# Altium schematic sheet IMU.SchDoc
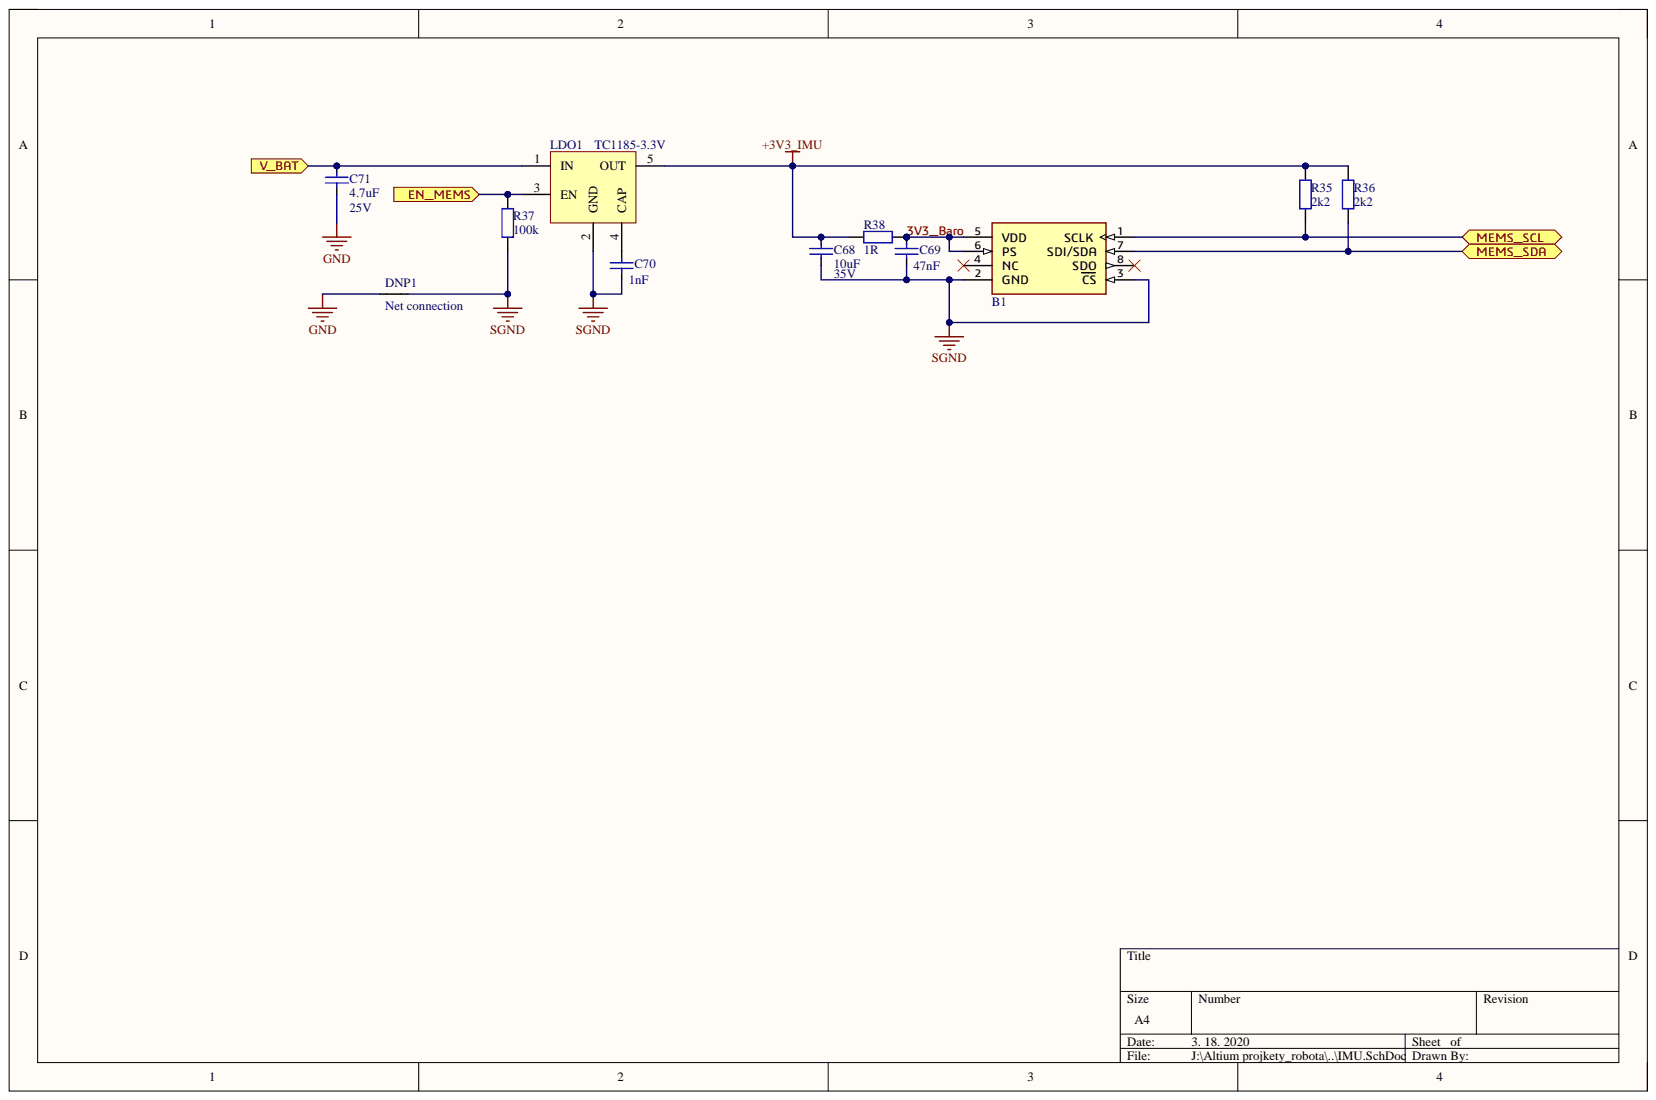
[diagram p0: IMU.SchDoc]

## Parts
B1  Pressure sensor  [MS580314]  (value not drawn)
C68  10uF  Voltage Rating: 35V  [CAPACITOR_0805_(2012)]
C69  47nF  [CAPACITOR_0603_(1608)]
C70  1nF  [CAPACITOR_0603_(1608)]
C71  4.7uF  Voltage: 25V  [CAPACITOR_0603_(1608)]
DNP1  Net connection  [Net connection 1mm]
LDO1  TC1185-3.3V  [SOT23-5]
R35  2k2  [RESISTOR_0603_(1608)]
R36  2k2  [RESISTOR_0603_(1608)]
R37  100k  [RESISTOR_0603_(1608)]
R38  1R  [RESISTOR_0603_(1608)]

## Ports
EN_MEMS, MEMS_SCL, MEMS_SDA, V_BAT

## Nets
GND: C71.2 DNP1.1
SGND: B1.2(GND) B1.3(CS) C68.2 C69.2 C70.2 DNP1.2 LDO1.2(GND) R37.1
3V3_Baro: B1.5(VDD) B1.6(PS) C68.1 C69.1 LDO1.5(OUT) R35.1 R36.1 R38.1 R38.2
EN_MEMS: LDO1.3(EN) R37.2 ; port EN_MEMS
MEMS_SCL: B1.1(SCLK) R35.2 ; port MEMS_SCL
MEMS_SDA: B1.7(SDI/SDA) R36.2 ; port MEMS_SDA
V_BAT: C71.1 LDO1.1(IN) ; port V_BAT
NetC70_1: C70.1 LDO1.4(CAP)

Not connected: B1 4(NC) 8(SDO)

## Library data of the parts
B1 = MS5803: Miniature 14 bar Module; Component Kind: Standard; Library Name: BB1_SCH_Library.SchLib
C68 = CAPACITOR: Manufacturer 1: Murata; Manufacturer Part Number 1: GRM21BR6YA106KE43L; Dielectric: X5R; Tolerance: 10%; Capacitance: 10uF; Case Code (Imperial): 0805; Case Code (Metric): 2012; Case/Package: 0805; Component Kind: Standard; ComponentLink1Description: http://www.farnell.com/datasheets/2079187.pdf?src-supplier=Farnell; ComponentLink1URL: http://www.farnell.com/datasheets/2079187.pdf?src-supplier=Farnell; ComponentLink2Description: http://www.mouser.com/ds/2/281/c02e-2905.pdf?src-supplier=Mouser; ComponentLink2URL: http://www.mouser.com/ds/2/281/c02e-2905.pdf?src-supplier=Mouser; ComponentLink3Description: http://search.murata.co.jp/Ceramy/image/img/A01X/G101/ENG/GRM21BR6YA106KE43-01.pdf?src-supplier=Digi-Key; ComponentLink3URL: http://search.murata.co.jp/Ceramy/image/img/A01X/G101/ENG/GRM21BR6YA106KE43-01.pdf?src-supplier=Digi-Key; ComponentLink4Description: http://search.murata.co.jp/Ceramy/image/img/A01X/partnumbering_e_01.pdf?src-supplier=Digi-Key; ComponentLink4URL: http://search.murata.co.jp/Ceramy/image/img/A01X/partnumbering_e_01.pdf?src-supplier=Digi-Key; ComponentLink5Description: http://www.farnell.com/datasheets/2079187.pdf?src-supplier=Newark; ComponentLink5URL: http://www.farnell.com/datasheets/2079187.pdf?src-supplier=Newark; ComponentLink6Description: http://www.farnell.com/datasheets/2079187.pdf?src-supplier=Element14; ComponentLink6URL: http://www.farnell.com/datasheets/2079187.pdf?src-supplier=Element14; Description: CAPACITOR; Height: 1.25mm; Lead Free: Lead Free; Length: 0.079inch; Max Operating Temperature: 85°C; Min Operating Temperature: -55°C; Mount: Surface Mount; Package Quantity: 3000; Packaging: Tape and Reel; Pricing 1: 5=0,38700, 50=0,21000, 250=0,18000, 500=0,11500, 1500=0,10500, 3000=0,09410, 15000=0,09070 (EUR); REACH SVHC: No SVHC; RoHS: Yes; Stock 1: 109244; Supplier 1: Farnell; Supplier Part Number 1: 2611943; Termination: SMD/SMT; Thickness: 0.057inch; Voltage Rating (DC): 35V; Weight: 0.000335oz; Width: 0.049inch; Library Name: RLCFB.SchLib
C69 C70 = CAPACITOR: ESR: 0.28R; Voltage Rating: 10V; Component Kind: Standard; Description: CAPACITOR; Library Name: RLCFB.SchLib
  C69: Capacitance: 47nF
  C70: Capacitance: 1nF
C71 = CAPACITOR: Manufacturer 1: Murata; Manufacturer Part Number 1: GRM188R61E475KE11D; Dielectric: X5R; ESR: 0.28R; Material: Ceramic; Tolerance: 10%; Capacitance: 4.7uF; Case Code (Imperial): 0603; Case Code (Metric): 1608; Case/Package: 0603; Component Kind: Standard; ComponentLink1Description: https://datasheet.ciiva.com/46444/1769893-46444982.pdf?src-supplier=Element14; ComponentLink1URL: https://datasheet.ciiva.com/46444/1769893-46444982.pdf?src-supplier=Element14; ComponentLink2Description: https://datasheet.ciiva.com/46444/1769893-46444983.pdf?src-supplier=Element14; ComponentLink2URL: https://datasheet.ciiva.com/46444/1769893-46444983.pdf?src-supplier=Element14; ComponentLink3Description: https://datasheet.ciiva.com/14891/partnumbering-e-01-14891102.pdf?src-supplier=Digi-Key; ComponentLink3URL: https://datasheet.ciiva.com/14891/partnumbering-e-01-14891102.pdf?src-supplier=Digi-Key; ComponentLink4Description: https://datasheet.ciiva.com/14891/partnumbering-e-01-14891103.pdf?src-supplier=Digi-Key; ComponentLink4URL: https://datasheet.ciiva.com/14891/partnumbering-e-01-14891103.pdf?src-supplier=Digi-Key; Depth: 0.8mm; Description: CAPACITOR; Height: 0.8mm; Lead Free: Lead Free; Length: 1.6mm; Max Operating Temperature: 85°C; Min Operating Temperature: -55°C; Mount: Surface Mount; Package Quantity: 4000; Packaging: Cut Tape; Pricing 1: 10=0,35700, 100=100,00000, 500=0,15900, 1000=0,12800, 2000=0,10200 (CHF); REACH SVHC: No SVHC; RoHS: Yes; Stock 1: 95119; Supplier 1: Farnell; Supplier Part Number 1: 2426959; Thickness: 0.031inch; Voltage Rating: 25V; Voltage Rating (DC): 25V; Width: 0.031inch; Library Name: RLCFB.SchLib
DNP1 = Net connection: Connect two nets with different names on pcb; Component Kind: Standard; Library Name: Boomblaster.SchLib
LDO1 = TC1185-3.3V: TC1185-3.3VCT713; Manufacturer 1: Microchip; Accuracy: 2.5%; Dropout Voltage: 270mV; Max Input Voltage: 6V; Max Output Current: 150mA; Max Output Voltage: 3.3V; Min Input Voltage: 2.7V; Number of Outputs: 1; Output Type: Fixed; Polarity: Positive; Power Dissipation: 318mW; Row Spacing: 3.1mm; Case/Package: SOT-23; Component Kind: Standard; ComponentLink1Description: https://datasheet.ciiva.com/41463/001085557-da-01-en-ic-reg-ldo-tc1185-3-3vct713-sot-23-5-mcp-41463243.pdf?src-supplier=Conrad; ComponentLink1URL: https://datasheet.ciiva.com/41463/001085557-da-01-en-ic-reg-ldo-tc1185-3-3vct713-sot-23-5-mcp-41463243.pdf?src-supplier=Conrad; ComponentLink2Description: https://datasheet.ciiva.com/2040/filehandler-aspxddocname-en026002-2040128.pdf?src-supplier=Digi-Key; ComponentLink2URL: https://datasheet.ciiva.com/2040/filehandler-aspxddocname-en026002-2040128.pdf?src-supplier=Digi-Key; ComponentLink3Description: https://datasheet.ciiva.com/2040/filehandler-aspxddocname-en026002-2040129.pdf?src-supplier=Digi-Key; ComponentLink3URL: https://datasheet.ciiva.com/2040/filehandler-aspxddocname-en026002-2040129.pdf?src-supplier=Digi-Key; ComponentLink4Description: https://datasheet.ciiva.com/14562/70046349-14562375.pdf?src-supplier=Allied+Electronics; ComponentLink4URL: https://datasheet.ciiva.com/14562/70046349-14562375.pdf?src-supplier=Allied+Electronics; ComponentLink5Description: https://datasheet.ciiva.com/16427/21335e-16427447.pdf?src-supplier=Future+Electronics; ComponentLink5URL: https://datasheet.ciiva.com/16427/21335e-16427447.pdf?src-supplier=Future+Electronics; ComponentLink6Description: https://datasheet.ciiva.com/17362/jp011417-17362376.pdf?src-supplier=Avnet+Europe; ComponentLink6URL: https://datasheet.ciiva.com/17362/jp011417-17362376.pdf?src-supplier=Avnet+Europe; ComponentLink7Description: https://datasheet.ciiva.com/8239/jp011417-8239225.pdf?src-supplier=Avnet; ComponentLink7URL: https://datasheet.ciiva.com/8239/jp011417-8239225.pdf?src-supplier=Avnet; ComponentLink8Description: https://datasheet.ciiva.com/2040/filehandler-aspxddocname-en026002-2040127.pdf?src-supplier=Digi-Key; ComponentLink8URL: https://datasheet.ciiva.com/2040/filehandler-aspxddocname-en026002-2040127.pdf?src-supplier=Digi-Key; ComponentLink9Description: https://datasheet.ciiva.com/8041/21335e-8041567.pdf?src-supplier=Element14; ComponentLink9URL: https://datasheet.ciiva.com/8041/21335e-8041567.pdf?src-supplier=Element14; Height: 0.051inch; Lead Free: Lead Free; Lead Pitch: 0.95mm; Length: 0.122inch; Library Name: BB1_SCH_Library.SchLib; Manufacturer Part Number 1: TC1185-3.3VCT713; Max Operating Temperature: 125°C; Max Supply Voltage: 6V; Min Operating Temperature: -40°C; Min Output Voltage: 3.3V; Min Supply Voltage: 2.7V; Mount: Surface Mount; Nominal Output Voltage: 3.3V; Number of Pins: 5; Number of Regulators: 1; Output Current: 150mA; Output Voltage: 3.3V; Package Quantity: 1; Packaging: Cut Tape; Pricing 1: 1=0,34100, 10=0,33800, 25=0,28600, 50=0,26900, 100=0,25100 (EUR); Radiation Hardening: No; REACH SVHC: No SVHC; RoHS: Yes; Stock 1: 7492; Supplier 1: Farnell; Supplier Part Number 1: 9762540; Voltage: 3.3V; Width: 0.071inch
R35-R38 = RESISTOR: Power Rating: 500mW; Component Kind: Standard; Description: RESISTOR; Library Name: RLCFB.SchLib
  R35 R36: Resistance: 2k2
  R37: Resistance: 100k
  R38: Resistance: 1R
